# Altium schematic sheet CORE.SchDoc(CORE)
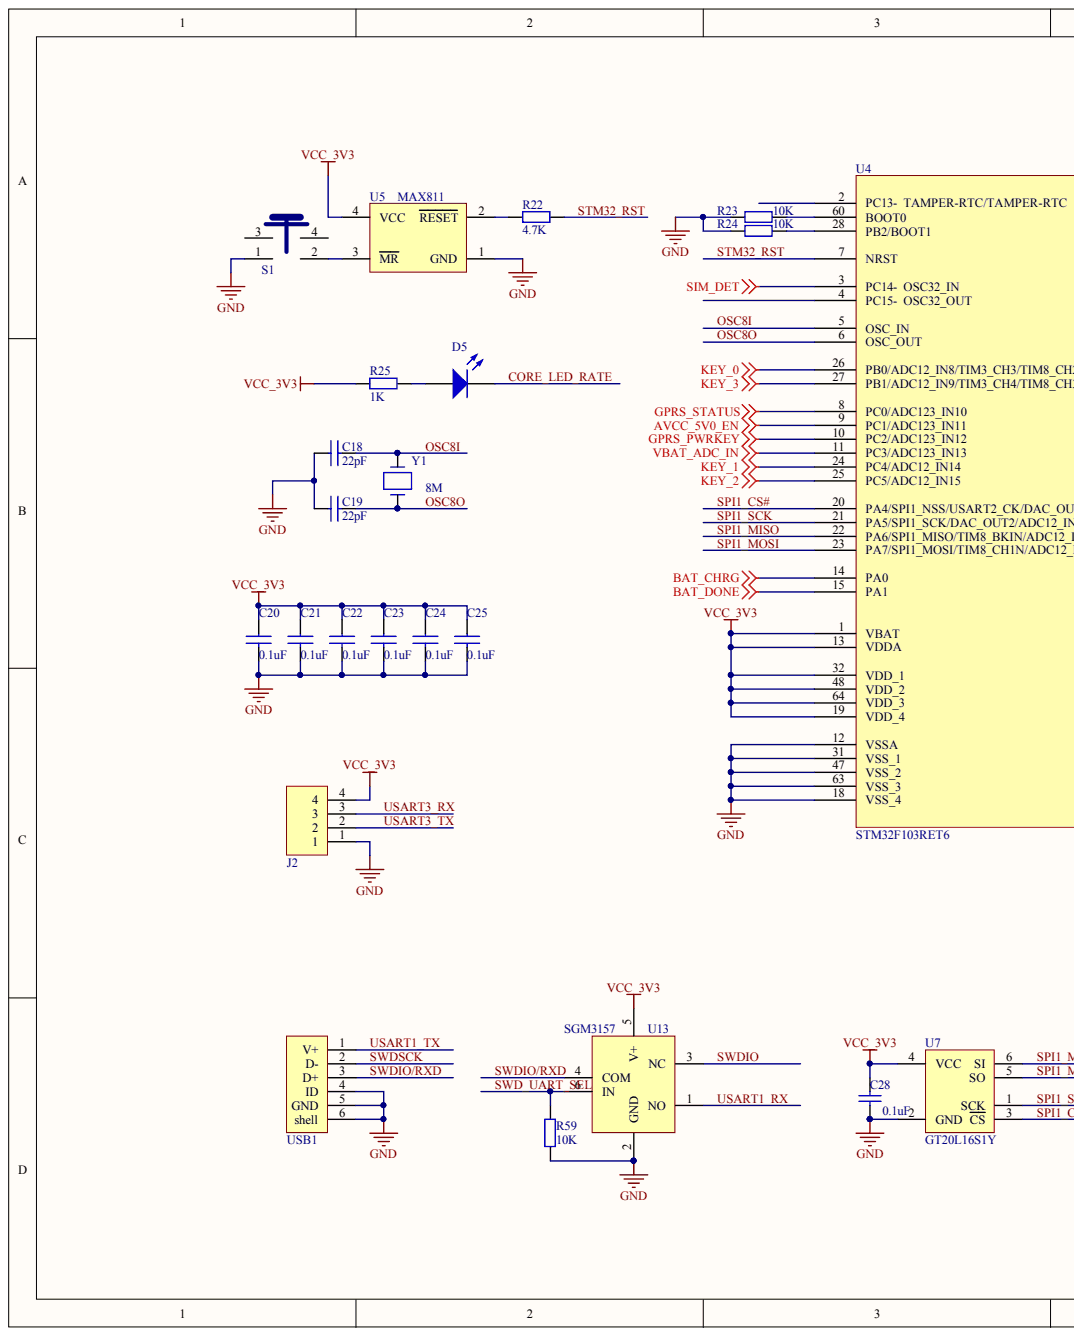
[diagram p0: CORE.SchDoc, left]
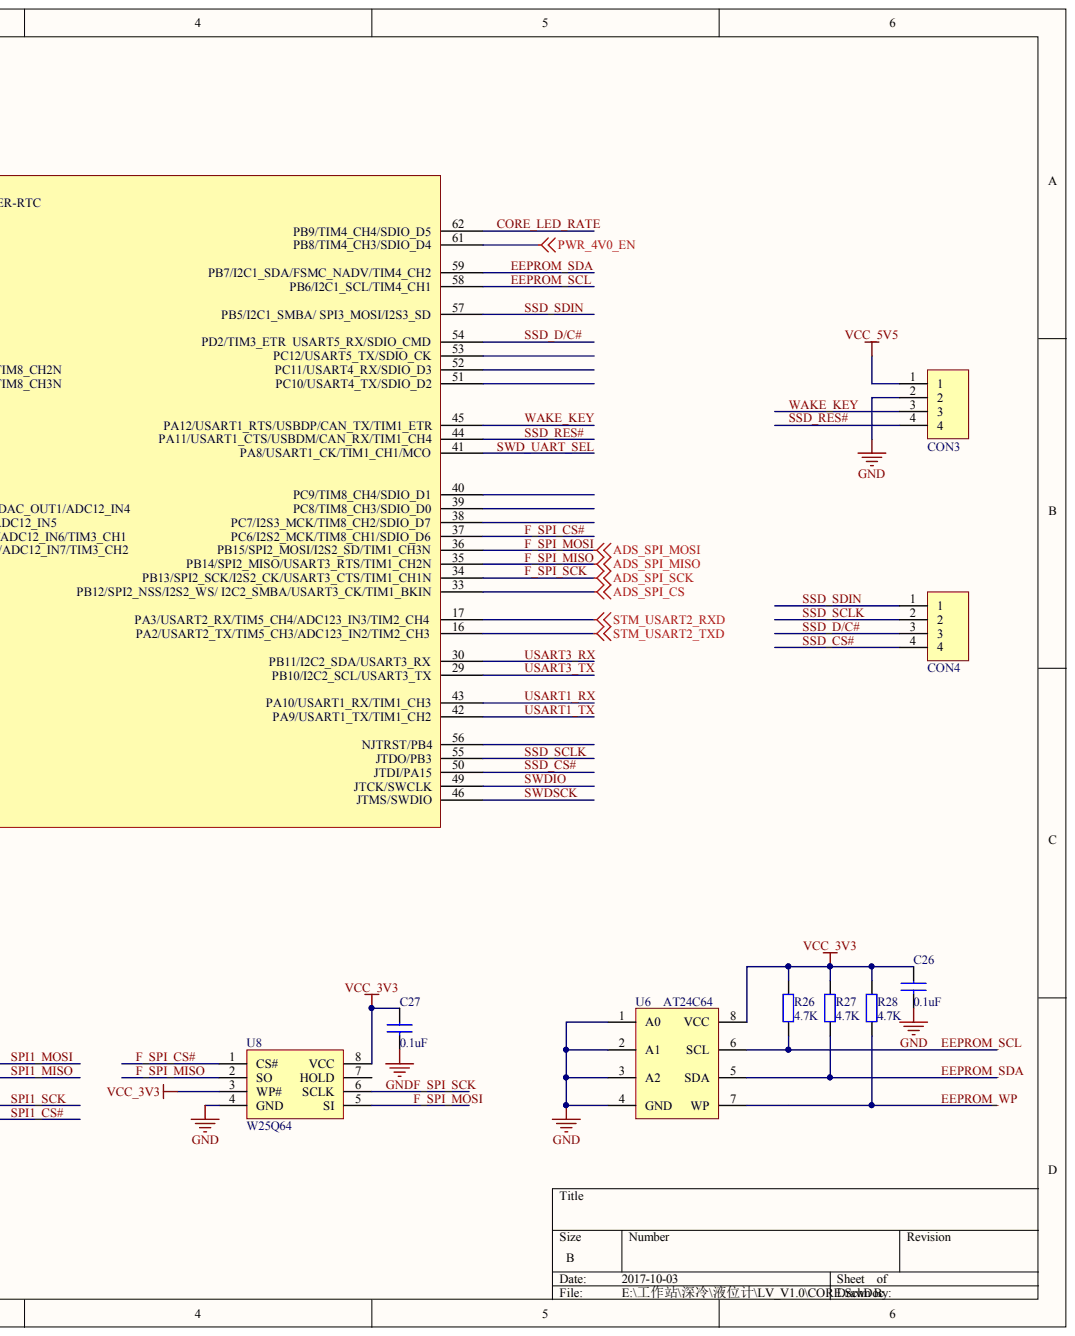
[diagram p1: CORE.SchDoc, right]

## Parts
C18  value 22pF  [0603C]  (hidden comment: CAP NP)
C19  value 22pF  [0603C]  (hidden comment: CAP NP)
C20  value 0.1uF  [0603C]  (hidden comment: CAP NP)
C21  value 0.1uF  [0603C]  (hidden comment: CAP NP)
C22  value 0.1uF  [0603C]  (hidden comment: CAP NP)
C23  value 0.1uF  [0603C]  (hidden comment: CAP NP)
C24  value 0.1uF  [0603C]  (hidden comment: CAP NP)
C25  value 0.1uF  [0603C]  (hidden comment: CAP NP)
C26  value 0.1uF  [0603C]  (hidden comment: CAP NP)
C27  value 0.1uF  [0603C]  (hidden comment: CAP NP)
C28  value 0.1uF  [0603C]  (hidden comment: Capacitor)
CON3  CONNECTOR_4PIN  [FPC1.0-4P]  (value not drawn)
CON4  CONNECTOR_4PIN  [FPC1.0-4P]  (value not drawn)
D5  LED0  [LED0805]  (value not drawn)
J2  CONNECTOR_4PIN  [FPC1.0-4P]  (value not drawn)
R22  value 4.7K  [L0603]  (hidden comment: Resistor)
R23  value 10K  [L0603]  (hidden comment: Resistor)
R24  value 10K  [L0603]  (hidden comment: Resistor)
R25  value 1K  [L0603]  (hidden comment: Resistor)
R26  value 4.7K  [L0603]  (hidden comment: Resistor)
R27  value 4.7K  [L0603]  (hidden comment: Resistor)
R28  value 4.7K  [L0603]  (hidden comment: Resistor)
R59  value 10K  [L0603]  (hidden comment: Resistor)
S1  KEY  [4.5X4.5X4.5]  (value not drawn)
U4  STM32F103RET6  [LQFP64]
U5  MAX811  [SOD143]
U6  AT24C64  [SOP8]
U7  GT20L16S1Y  [DDC]
U8  W25Q64  [SOP8]
U13  SGM3157  [SC70-6L]
USB1  Mini USB  [MicroUSB]  (value not drawn)
Y1  value 8M  [ABM7]  (hidden comment: CRYSTAL_0)

## Nets
ADS_SPI_CS: U4.33(PB12/SPI2_NSS/I2S2_WS/_I2C2_SMBA/USART3_CK/TIM1_BKIN)
AVCC_5V0_EN: U4.9(PC1/ADC123_IN11)
BAT_CHRG: U4.14(PA0)
BAT_DONE: U4.15(PA1)
GND: C18.1 C19.1 C20.2 C21.2 C22.2 C23.2 C24.2 C25.2 C26.2 C27.2 C28.2 CON3.2 J2.1 R23.1 R24.1 R59.2 S1.1 U4.12(VSSA) U4.18(VSS_4) U4.31(VSS_1) U4.47(VSS_2) U4.63(VSS_3) U5.1(GND) U6.1(A0) U6.2(A1) U6.3(A2) U6.4(GND) U7.2(GND) U8.4(GND) U13.2(GND) USB1.4(ID) USB1.5(GND) USB1.6(shell)
GPRS_PWRKEY: U4.10(PC2/ADC123_IN12)
GPRS_STATUS: U4.8(PC0/ADC123_IN10)
KEY_0: U4.26(PB0/ADC12_IN8/TIM3_CH3/TIM8_CH2N)
KEY_1: U4.24(PC4/ADC12_IN14)
KEY_2: U4.25(PC5/ADC12_IN15)
KEY_3: U4.27(PB1/ADC12_IN9/TIM3_CH4/TIM8_CH3N)
PWR_4V0_EN: U4.61(PB8/TIM4_CH3/SDIO_D4)
SIM_DET: U4.3(PC14-)
STM_USART2_RXD: U4.17(PA3/USART2_RX/TIM5_CH4/ADC123_IN3/TIM2_CH4)
STM_USART2_TXD: U4.16(PA2/USART2_TX/TIM5_CH3/ADC123_IN2/TIM2_CH3)
VBAT_ADC_IN: U4.11(PC3/ADC123_IN13)
VCC_3V3: C20.1 C21.1 C22.1 C23.1 C24.1 C25.1 C26.1 C27.1 C28.1 J2.4 R25.2 R26.1 R27.1 R28.1 U4.1(VBAT) U4.13(VDDA) U4.19(VDD_4) U4.32(VDD_1) U4.48(VDD_2) U4.64(VDD_3) U5.4(VCC) U6.8(VCC) U7.4(VCC) U8.3(WP#) U8.8(VCC) U13.5(V+)
VCC_5V5: CON3.1
CORE_LED_RATE: D5.2 U4.62(PB9/TIM4_CH4/SDIO_D5)
EEPROM_SCL: R26.2 U4.58(PB6/I2C1_SCL/TIM4_CH1) U6.6(SCL)
EEPROM_SDA: R27.2 U4.59(PB7/I2C1_SDA/FSMC_NADV/TIM4_CH2) U6.5(SDA)
EEPROM_WP: R28.2 U6.7(WP)
F_SPI_CS#: U4.37(PC6/I2S2_MCK/TIM8_CH1/SDIO_D6) U8.1(CS#)
F_SPI_MISO: U4.35(PB14/SPI2_MISO/USART3_RTS/TIM1_CH2N) U8.2(SO)
F_SPI_MOSI: U4.36(PB15/SPI2_MOSI/I2S2_SD/TIM1_CH3N) U8.5(SI)
F_SPI_SCK: U4.34(PB13/SPI2_SCK/I2S2_CK/USART3_CTS/TIM1_CH1N) U8.6(SCLK)
OSC8I: C18.2 U4.5(OSC_IN) Y1.1
OSC8O: C19.2 U4.6(OSC_OUT) Y1.2
SPI1_CS#: U4.20(PA4/SPI1_NSS/USART2_CK/DAC_OUT1/ADC12_IN4) U7.3(CS)
SPI1_MISO: U4.22(PA6/SPI1_MISO/TIM8_BKIN/ADC12_IN6/TIM3_CH1) U7.5(SO)
SPI1_MOSI: U4.23(PA7/SPI1_MOSI/TIM8_CH1N/ADC12_IN7/TIM3_CH2) U7.6(SI)
SPI1_SCK: U4.21(PA5/SPI1_SCK/DAC_OUT2/ADC12_IN5) U7.1(SCK)
SSD_CS#: CON4.4 U4.50(JTDI/PA15)
SSD_D/C#: CON4.3 U4.54(USART5_RX/SDIO_CMD)
SSD_RES#: CON3.4 U4.44(PA11/USART1_CTS/USBDM/CAN_RX/TIM1_CH4)
SSD_SCLK: CON4.2 U4.55(JTDO/PB3)
SSD_SDIN: CON4.1 U4.57(PB5/I2C1_SMBA/_SPI3_MOSI/I2S3_SD)
STM32_RST: R22.1 U4.7(NRST)
SWD_UART_SEL: R59.1 U4.41(PA8/USART1_CK/TIM1_CH1/MCO) U13.6(IN)
SWDIO: U4.49(JTCK/SWCLK) U13.3(NC)
SWDIO/RXD: U13.4(COM) USB1.3(D+)
SWDSCK: U4.46(JTMS/SWDIO) USB1.2(D-)
USART1_RX: U4.43(PA10/USART1_RX/TIM1_CH3) U13.1(NO)
USART1_TX: U4.42(PA9/USART1_TX/TIM1_CH2) USB1.1(V+)
USART3_RX: J2.3 U4.30(PB11/I2C2_SDA/USART3_RX)
USART3_TX: J2.2 U4.29(PB10/I2C2_SCL/USART3_TX)
WAKE_KEY: CON3.3 U4.45(PA12/USART1_RTS/USBDP/CAN_TX/TIM1_ETR)
NetD5_1: D5.1 R25.1
NetR22_2: R22.2 U5.2(RESET)
NetR23_2: R23.2 U4.60(BOOT0)
NetR24_2: R24.2 U4.28(PB2/BOOT1)
NetS1_2: S1.2 U5.3(MR)

Not connected: S1 3 4; U4 2(PC13-) 4(PC15-) 38(PC7/I2S3_MCK/TIM8_CH2/SDIO_D7) 39(PC8/TIM8_CH3/SDIO_D0) 40(PC9/TIM8_CH4/SDIO_D1) 51(PC10/USART4_TX/SDIO_D2) 52(PC11/USART4_RX/SDIO_D3) 53(PC12/USART5_TX/SDIO_CK) 56(NJTRST/PB4); U8 7(HOLD)

## Library data of the parts
C28 = Cap: Component Kind: Standard; Description: Capacitor; LatestRevisionDate: 17-Jul-2002; LatestRevisionNote: Re-released for DXP Platform.; Library Name: Lib1.SCHLIB; PackageDescription: Radial Cap, Thru-Hole; 2 Leads; 0.3 in Pin Spacing; PackageReference: RAD-0.3; Published: 8-Jun-2000; Signal Integrity: Cap; Simulation: CAP; Publisher: Altium Limited
D5 = LED0805: Typical INFRARED GaAs LED; Value: LED_5mm_RED; Component Kind: Standard; LatestRevisionDate: 08-Jul-2005; LatestRevisionNote: Mech Layer 1 removed.; PackageDescription: LED; 2 Leads; PackageReference: LED-0; Published: 8-Jun-2000; Library Name: 简配版生物罐.SCHLIB; Publisher: Altium Limited
J2 = CONNECTOR_4PIN: Value: XH2.54-4; Component Kind: Standard; Library Name: Lib1.SCHLIB
R22-R28 R59 = Res: Component Kind: Standard; Library Name: Lib1.SCHLIB
U4 = STM32F103RBT6: Component Kind: Standard; Value: STM32F103RET6; Library Name: Bio.SchLib
U6 = AT24C16: Component Kind: Standard; Library Name: MyLib.SCHLIB
USB1 = Mini USB 5Pin: Component Kind: Standard; Library Name: zhileng.SCHLIB
C18-C27 = CAP NP: Component Kind: Standard; Library Name: MAINBOARD_Library.SchLib
CON3 CON4 = CONNECTOR_4PIN: Component Kind: Standard; Library Name: MyLib.SCHLIB
S1 = KEY: Component Kind: Standard; Value: KEY; Library Name: Bio.SchLib
U5 = MAX811: Component Kind: Standard; Value: MAX811; Library Name: LNG.SCHLIB
U7 = GT20L16S1Y: Component Kind: Standard; Library Name: MyLib.SCHLIB
U8 = W25Q64: Component Kind: Standard; Value: W25Q64; Library Name: Bio.SchLib
U13 = SGM3157: Component Kind: Standard; Library Name: zhileng.SCHLIB
Y1 = CRYSTAL_0: Component Kind: Standard; Library Name: MAINBOARD_Library.SchLib
